# Altium schematic sheet Power Input and Regulators.SchDoc("Power Input and Regulators")
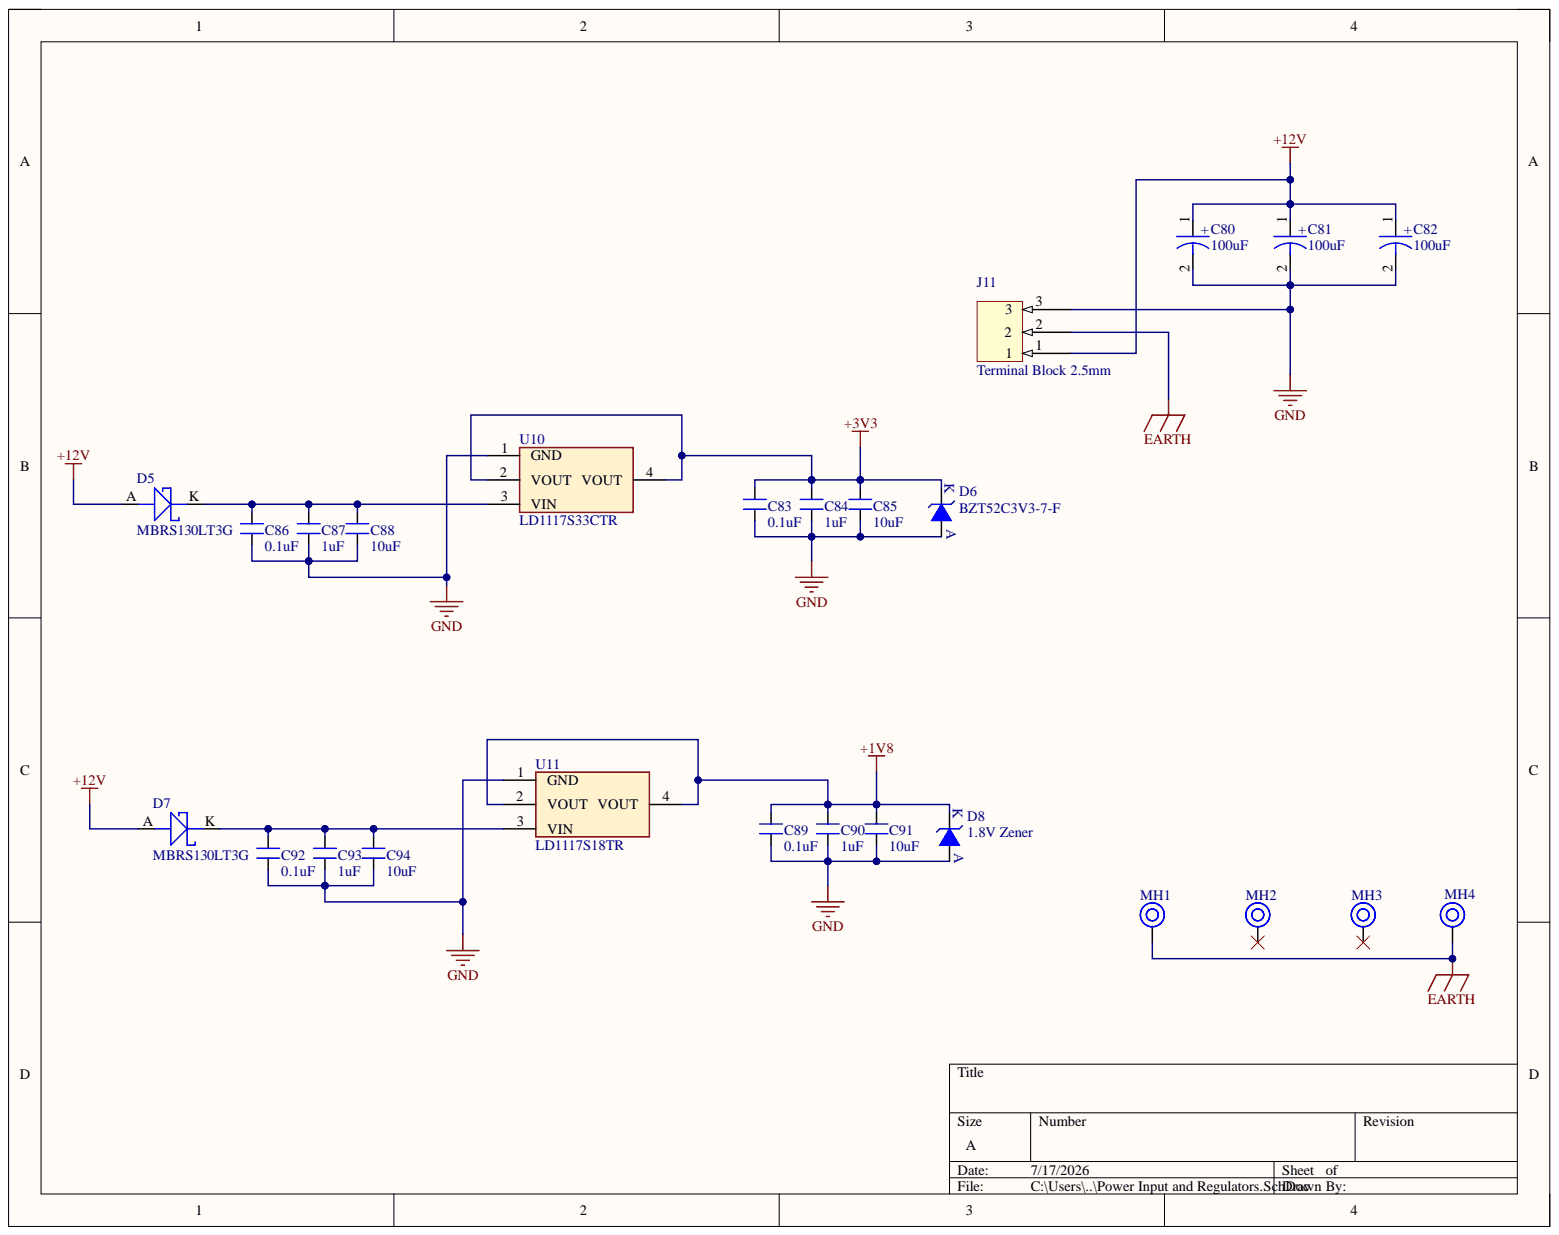
[diagram p0: Power Input and Regulators.SchDoc]

## Parts
C80  100uF  [ACAP_P305_D393_H393_RAD]
C81  100uF  [ACAP_P305_D393_H393_RAD]
C82  100uF  [ACAP_P305_D393_H393_RAD]
C83  0.1uF  [CAP_0805]
C84  1uF  [CAP_0805]
C85  10uF  [CAP_1206]
C86  0.1uF  [CAP_0805]
C87  1uF  [CAP_0805]
C88  10uF  [CAP_1206]
C89  0.1uF  [CAP_0805]
C90  1uF  [CAP_0805]
C91  10uF  [CAP_1206]
C92  0.1uF  [CAP_0805]
C93  1uF  [CAP_0805]
C94  10uF  [CAP_1206]
D5  MBRS130LT3G  [DIO_SMB_DO-214AA]
D6  BZT52C3V3-7-F  [DIO_SOD-123]
D7  MBRS130LT3G  [DIO_SMB_DO-214AA]
D8  1.8V Zener  [DIO_DO-213AB_SMD]
J11  Terminal Block 2.5mm  [CON3_100_TB_TH_ST]
MH1  Mounting Hole  [MOUNTING]  (value not drawn)
MH2  Mounting Hole  [MOUNTING]  (value not drawn)
MH3  Mounting Hole  [MOUNTING]  (value not drawn)
MH4  Mounting Hole  [MOUNTING]  (value not drawn)
U10  LD1117S33CTR  [SOT223_3]
U11  LD1117S18TR  [SOT223_3]

## Nets
+1V8: C89.2 C90.2 C91.2 D8.K U11.2(VOUT) U11.4(VOUT)
+3V3: C83.2 C84.2 C85.2 D6.K U10.2(VOUT) U10.4(VOUT)
+12V: C80.1 C81.1 C82.1 D5.A D7.A J11.1
EARTH: J11.2 MH1.1 MH4.1
GND: C80.2 C81.2 C82.2 C83.1 C84.1 C85.1 C86.1 C87.1 C88.1 C89.1 C90.1 C91.1 C92.1 C93.1 C94.1 D6.A D8.A J11.3 U10.1(GND) U11.1(GND)
NetC86_2: C86.2 C87.2 C88.2 D5.K U10.3(VIN)
NetC92_2: C92.2 C93.2 C94.2 D7.K U11.3(VIN)

Not connected: MH2 1; MH3 1

## Library data of the parts
C80-C82 = CAP-P_100uF-50V-20%-RAD: 100µF 50V Aluminum Polymer Capacitor Radial, Can - SMD 28mOhm 4000 Hrs @ 125°C; Alternate Part Number-1: VEU101M1HTR-1010; Manufacturer: Nichicon; Manufacturer Part Number: GYA1H101MCQ1GS; Case/Package: Radial-SMD; Component Kind: Standard; Library Name: ESTEEMPCBLIB.IntLib; Supplier Part Number-1: GYA1H101MCQ1GS; Supplier-1: SP ROAD
C83 C86 C89 C92 = CAP_0.1uF-50V-10%-0805: 0.1µF ±10% 50V Ceramic Capacitor X7R 0805 (2012 Metric); Alternate Part Number-1: NA; Manufacturer: Samsung; Manufacturer Part Number: CL21B104KBCNNNC; Case/Package: 0805; Component Kind: Standard; Library Name: ESTEEMPCBLIB.IntLib; Supplier Part Number-1: 0805B104K500CT; Supplier-1: SIRICOM
C84 C87 C90 C93 = CAP_1uF-50V-5%-0805: 1µF ±5% 50V Ceramic Capacitor X7R 0805 (2012 Metric); Alternate Part Number-1: 0805F105M500NT; Manufacturer: Samsung; Manufacturer Part Number: CC0805JKX7R9BB105; Case/Package: 0805; Component Kind: Standard; Library Name: ESTEEMPCBLIB.IntLib; Supplier Part Number-1: CL21B105KBFNNNE; Supplier-1: SIRICOM
C85 C88 C91 C94 = CAP_10uF-50V-10%-1206: 10µF ±10% 50V Ceramic Capacitor X7R 1206 (3216 Metric); Alternate Part Number-1: GRT31CR61H106ME01L; Manufacturer: KEMET; Manufacturer Part Number: CL31B106KBHNNNE; Case/Package: 1206; Component Kind: Standard; Library Name: ESTEEMPCBLIB.IntLib; Supplier Part Number-1: CC1206KKX5R9BB106; Supplier-1: SIRICOM
D5 D7 = DIO-S_445mV-30V-2A-SMB: Diode Schottky 30V 2A Surface Mount SMB; Alternate Part Number-1: NA; Manufacturer: ON Semiconductor; Case/Package: SMB; Component Kind: Standard; Library Name: ESTEEMPCBLIB.IntLib; Manufacturer Part Number: MBRS130LT3G; Supplier Part Number-1: MBRS130LT3G; Supplier-1: ARROW
D6 = DIO-Z_3.3V-500mW-SOD123: Zener Diode 3.3V 500mW ±6% Surface Mount SOD-123; Alternate Part Number-1: NA; Manufacturer: Diodes Incorporated; Case/Package: SOD-123; Component Kind: Standard; Library Name: ESTEEMPCBLIB.IntLib; Manufacturer Part Number: BZT52C3V3-7-F; Supplier Part Number-1: BZT52C3V3-FDICT-ND; Supplier-1: Digikey
D8 = DIO-Z_1.8V-500mW-DO213AA: Zener Diode 1.8V 500mW ±5% Surface Mount DO-213AA; Component Kind: Standard; Library Name: ESTEEMPCBLIB.IntLib
J11 = CON-TB_3X1-100-ST-TH: 3 Pins, 1 Row 2.54mm Pitch, straight Connector, male; Alternate Part Number-1: NA; Manufacturer: Phoenix Contact; Manufacturer Part Number: 1725669; Case/Package: TH-CON; Component Kind: Standard; Library Name: ESTEEMPCBLIB.IntLib; Supplier Part Number-1: 1725669; Supplier-1: SP ROAD
MH1-MH4 = MH_3.5H_6D: Alternate Part Number-1: NA; Case/Package: TH; Component Kind: Standard; Description: Mounting Hole; Library Name: ESTEEMPCBLIB.IntLib; Manufacturer: NA; Manufacturer Part Number: NA; Supplier Part Number-1: NA; Supplier-1: NA
U10 = REG_15V-3.3V-0.9A-12W-SOT223: Linear Voltage Regulator IC 1 Output 800mA SOT-223; Alternate Part Number-1: NA; Manufacturer: STMicroelectronics; Case/Package: SOT223; Component Kind: Standard; Library Name: ESTEEMPCBLIB.IntLib; Manufacturer Part Number: LD1117S33CTR; Supplier Part Number-1: LD1117S33CTR; Supplier-1: SP ROAD
U11 = REG_15V-1.8V-0.8A-1.4W-SOT223: LDO Voltage Regulators 1.8V 0.8A Positive; Alternate Part Number-1: NA; Manufacturer: STMicroelectronics; Case/Package: SOT-223-3; Component Kind: Standard; Library Name: ESTEEMPCBLIB.IntLib; Manufacturer Part Number: LD1117S18TR; Supplier Part Number-1: 511-LD1117S18-TR; Supplier-1: Mouser
